# Altium schematic sheet OUT_B7.SchDoc
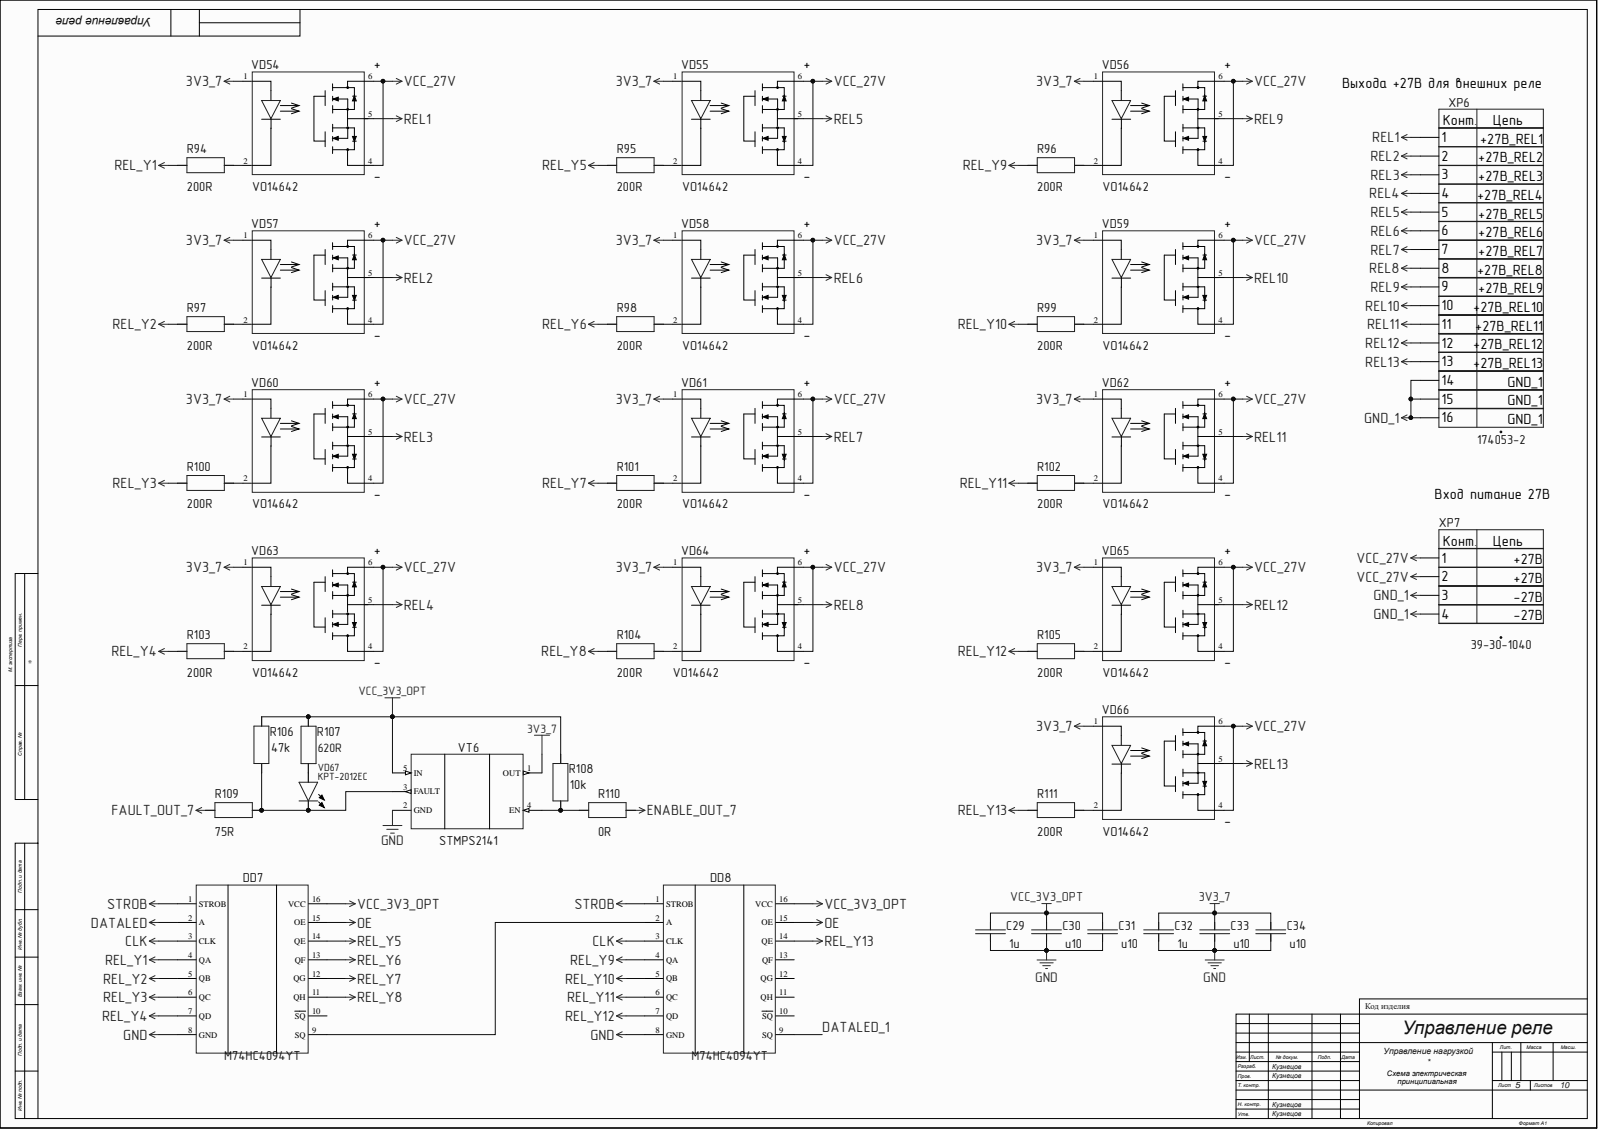
[diagram p0: OUT_B7.SchDoc]

## Parts
C29  1u
C30  u10
C31  u10
C32  1u
C33  u10
C34  u10
DD7  M74HC4094YT  [TSOOP-16]
DD8  M74HC4094YT  [TSOOP-16]
R94  200R  [0805]
R95  200R  [0805]
R96  200R  [0805]
R97  200R  [0805]
R98  200R  [0805]
R99  200R  [0805]
R100  200R  [0805]
R101  200R  [0805]
R102  200R  [0805]
R103  200R  [0805]
R104  200R  [0805]
R105  200R  [0805]
R106  47k  [0805]
R107  620R  [0805]
R108  10k  [0805]
R109  75R  [0805]
R110  0R  [0805]
R111  200R  [0805]
VD54  VO14642  [SMD-6]
VD55  VO14642  [SMD-6]
VD56  VO14642  [SMD-6]
VD57  VO14642  [SMD-6]
VD58  VO14642  [SMD-6]
VD59  VO14642  [SMD-6]
VD60  VO14642  [SMD-6]
VD61  VO14642  [SMD-6]
VD62  VO14642  [SMD-6]
VD63  VO14642  [SMD-6]
VD64  VO14642  [SMD-6]
VD65  VO14642  [SMD-6]
VD66  VO14642  [SMD-6]
VD67  KPT-2012EC  [0805_LED_RED]
VT6  STMPS2141  [SOT-23-5]
XP6  174053-2  [174053-2]
XP7  39-30-1040  [MINI-FIT JR - 4]

## Nets
3V3_7: C32.2 C33.2 C34.2 VD54.1 VD55.1 VD56.1 VD57.1 VD58.1 VD59.1 VD60.1 VD61.1 VD62.1 VD63.1 VD64.1 VD65.1 VD66.1 VT6.1(OUT)
CLK: DD7.3(CLK) DD8.3(CLK)
DATALED: DD7.2(A)
ENABLE_OUT_7: R110.1
FAULT_OUT_7: R109.2
GND: C29.1 C30.1 C31.1 C32.1 C33.1 C34.1 DD7.8(GND) DD8.8(GND) VT6.2(GND)
GND_1: XP6.14 XP6.15 XP6.16 XP7.3 XP7.4
OE: DD7.15(OE) DD8.15(OE)
REL1: VD54.5 XP6.1
REL2: VD57.5 XP6.2
REL3: VD60.5 XP6.3
REL4: VD63.5 XP6.4
REL5: VD55.5 XP6.5
REL6: VD58.5 XP6.6
REL7: VD61.5 XP6.7
REL8: VD64.5 XP6.8
REL9: VD56.5 XP6.9
REL10: VD59.5 XP6.10
REL11: VD62.5 XP6.11
REL12: VD65.5 XP6.12
REL13: VD66.5 XP6.13
REL_Y1: DD7.4(QA) R94.2
REL_Y2: DD7.5(QB) R97.2
REL_Y3: DD7.6(QC) R100.2
REL_Y4: DD7.7(QD) R103.2
REL_Y5: DD7.14(QE) R95.2
REL_Y6: DD7.13(QF) R98.2
REL_Y7: DD7.12(QG) R101.2
REL_Y8: DD7.11(QH) R104.2
REL_Y9: DD8.4(QA) R96.2
REL_Y10: DD8.5(QB) R99.2
REL_Y11: DD8.6(QC) R102.2
REL_Y12: DD8.7(QD) R105.2
REL_Y13: DD8.14(QE) R111.2
STROB: DD7.1(STROB) DD8.1(STROB)
VCC_3V3_OPT: C29.2 C30.2 C31.2 DD7.16(VCC) DD8.16(VCC) R106.1 R107.2 R108.1 VT6.5(IN)
VCC_27V: VD54.4 VD54.6 VD55.4 VD55.6 VD56.4 VD56.6 VD57.4 VD57.6 VD58.4 VD58.6 VD59.4 VD59.6 VD60.4 VD60.6 VD61.4 VD61.6 VD62.4 VD62.6 VD63.4 VD63.6 VD64.4 VD64.6 VD65.4 VD65.6 VD66.4 VD66.6 XP7.1 XP7.2
DATALED_1: DD8.9(SQ)
NetDD7_9: DD7.9(SQ) DD8.2(A)
NetR94_1: R94.1 VD54.2
NetR95_1: R95.1 VD55.2
NetR96_1: R96.1 VD56.2
NetR97_1: R97.1 VD57.2
NetR98_1: R98.1 VD58.2
NetR99_1: R99.1 VD59.2
NetR100_1: R100.1 VD60.2
NetR101_1: R101.1 VD61.2
NetR102_1: R102.1 VD62.2
NetR103_1: R103.1 VD63.2
NetR104_1: R104.1 VD64.2
NetR105_1: R105.1 VD65.2
NetR106_2: R106.2 R109.1 VD67.2 VT6.3(FAULT)
NetR107_1: R107.1 VD67.1
NetR108_2: R108.2 R110.2 VT6.4(EN)
NetR111_1: R111.1 VD66.2

Not connected: DD7 10(SQ); DD8 10(SQ) 11(QH) 12(QG) 13(QF)

## Library data of the parts
C29-C34 = (no symbol name): Capacitor; Power\Voltage: 25V; TKE: 5XR; Tolerance: 10%; Корпус: 0805; Component Kind: Standard; ComponentLink1Description: Параметры; ComponentLink2Description: Сайт производителя; Author: Eleman; Library Name: eleman_capacitor.schlib
  C29 C32: Value: 1u
  C30 C31 C33 C34: Value: u10
DD7 DD8 = M74HC4094YT: Микросхема  8-разрядный сдвиговый регистр; Корпус: 16-TSSOP; Component Kind: Standard; ComponentLink1Description: Параметры; ComponentLink2Description: Сайт производителя; ManufacturerPartNumber: M74HC4094YT; Author: Eleman; Library Name: Eleman_Logic.SchLib
R94-R111 = R0805: Импортный постоянный углеродистый резистор; Manufacturer: Vishay Dale; Power\Voltage: 0.125W; Tolerance: 5%; Component Kind: Standard; ComponentLink1Description: Параметры; ComponentLink2Description: Сайт производителя; ManufacturerPartNumber: R0805; Корпус: SMD 0805; Author: Eleman; Library Name: Eleman_Resistor.SchLib
  R94-R105 R111: Value: 200R
  R106: Value: 47k
  R107: Value: 620R
  R108: Value: 10k
  R109: Value: 75R
  R110: Value: 0R
VD54-VD66 = VO14642: Оптотранзистор; Manufacturer: Vishay Semiconductor Opto Division; Power\Voltage: IN 1.3V  50mA   OUT 2A 250mOhm; Корпус: 6-SMD; Component Kind: Standard; ComponentLink1Description: Параметры; ComponentLink2Description: Сайт производителя; ManufacturerPartNumber: VO14642; Supplier 1: Digi-Key; Supplier Part Number 1: VO14642AABTR-ND; Author: Eleman; ComponentLink1URL: d:\YandexDisk\Project_Altium\Lib_Eleman\; Library Name: Eleman_Opto.SchLib
VD67 = KPT-2012EC: Светодиод/Красный/Чип; Manufacturer: KINGBRIGHT; Power\Voltage: 20mA; Rem: Red; Component Kind: Standard; ComponentLink1Description: Параметры; ComponentLink2Description: Сайт производителя; ManufacturerPartNumber: KPT-2012EC; Value: KPT-2012EC; Корпус: SMD 0805; Author: Eleman; ComponentLink1URL: d:\YandexDisk\Project_Altium\Lib_Eleman\; Library Name: Eleman_Diods.SchLib
VT6 = STMPS2141: Транзистор; Корпус: SO-23-5; Component Kind: Standard; ComponentLink1Description: Параметры; ComponentLink2Description: Сайт производителя; ManufacturerPartNumber: STMPS2141; Author: Eleman; Library Name: Eleman_Driver.SchLib
XP6 = 174053-2: Разъем; Manufacturer: TE Connectivity AMP Connectors; Component Kind: Standard; ComponentLink1Description: Параметры; ComponentLink2Description: Сайт производителя; ManufacturerPartNumber: 174053-2; Author: Eleman; ComponentLink1URL: C:\Demo\Datasheet; Library Name: Eleman_Connector.SchLib
XP7 = 39-30-1040: Разъем; Manufacturer: Molex; Rem: Mini-Fit Jr 4; Корпус: Mini Fit; Component Kind: Standard; ComponentLink1Description: Параметры; ComponentLink2Description: Сайт производителя; ManufacturerPartNumber: 39-30-1040; Author: Eleman; ComponentLink1URL: C:\Demo\Datasheet; Library Name: Eleman_Connector.SchLib
